# Altium schematic sheet master_schematic.SchDoc
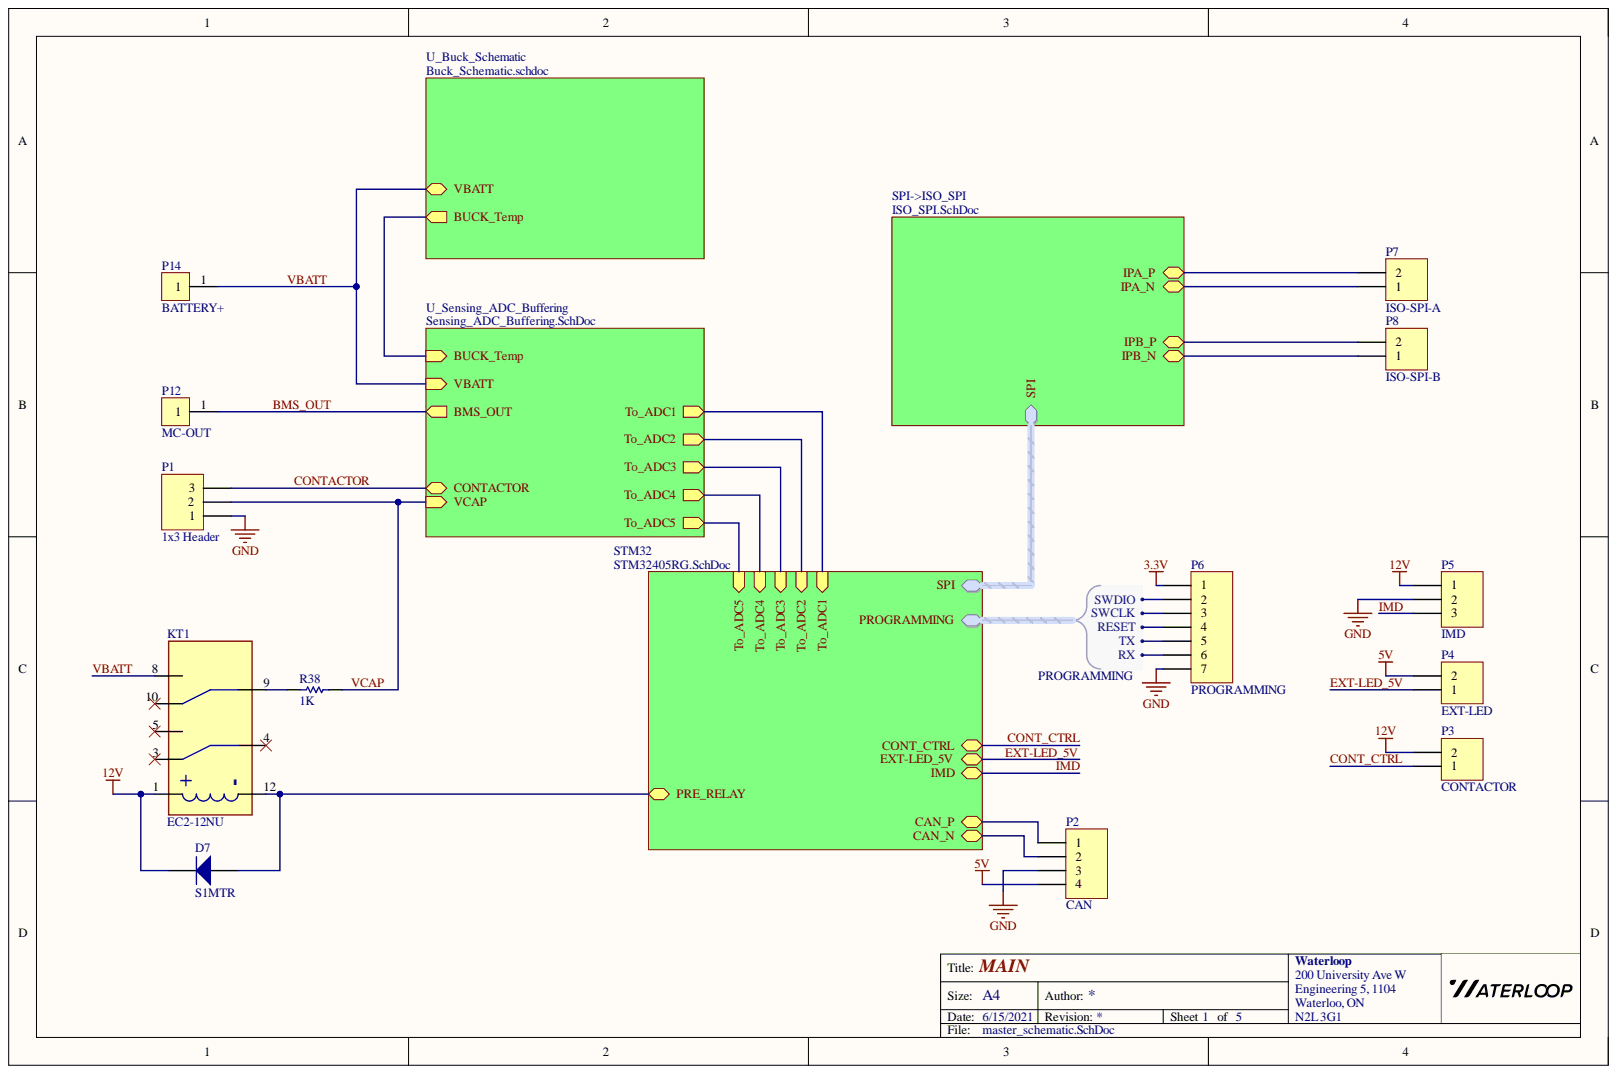
[diagram p0: master_schematic.SchDoc]

## Parts
D7  value S1MTR  [SMA - DIODE]  (hidden comment: Diode)
KT1  EC2-12NU  [EC2-12NU]
P1  1x3 Header  [B3B-XH]
P2  CAN  [B4B-XH]
P3  CONTACTOR  [B2B-XH]
P4  EXT-LED  [B2B-XH]
P5  IMD  [B3B-XH]
P6  PROGRAMMING  [HDR1X7]  (no BOM)
P7  ISO-SPI-A  [B2B-XH]
P8  ISO-SPI-B  [B2B-XH]
P12  MC-OUT  [74650094R]
P14  BATTERY+  [74650094R]
R38  1K  [2816 - RESISTOR]

## Nets
3.3V: P6.1
5V: P2.4 P4.2
12V: D7.2 KT1.1 P3.2 P5.1
GND: P1.1 P2.3 P5.2 P6.7
BMS_OUT: P12.1
CONT_CTRL: P3.1
CONTACTOR: P1.3
EXT-LED_5V: P4.1
IMD: P5.3
VBATT: KT1.8 P14.1
VCAP: P1.2 R38.2
NetD7_1: D7.1 KT1.12
NetKT1_3: KT1.3
NetKT1_4: KT1.4
NetKT1_5: KT1.5
NetKT1_9: KT1.9 R38.1
NetKT1_10: KT1.10
NetP2_1: P2.1
NetP2_2: P2.2
NetP6_2: P6.2
NetP6_3: P6.3
NetP6_4: P6.4
NetP6_5: P6.5
NetP6_6: P6.6
NetP7_1: P7.1
NetP7_2: P7.2
NetP8_1: P8.1
NetP8_2: P8.2
BUCK_Temp:
SPI.~{CS}:
SPI.~{CS2}:
SPI.CS:
SPI.MISO:
SPI.MOSI:
SPI.SCK:
To_ADC1:
To_ADC2:
To_ADC3:
To_ADC4:
To_ADC5:

## Library data of the parts
D7 = Diode: https://www.digikey.ca/en/products/detail/smc-diode-solutions/S1MTR/6022596; Component Kind: Standard; Library Name: General.SchLib; Signal Integrity: Diode; Simulation: DIODE
P1 P5 = 1x3 Header: 1x3 Pin Header; Component Kind: Standard; Library Name: GeneralConnectors.SchLib; Signal Integrity: Connector
P2 = 1x4 Header: 1x4 Pin Header; Component Kind: Standard; Library Name: GeneralConnectors.SchLib; Signal Integrity: Connector
P3 P4 P7 P8 = 1x2 Header: 1x2 Pin Header; Component Kind: Standard; Library Name: GeneralConnectors.SchLib; Signal Integrity: Connector
P6 = 1x7 Header: 1x7 Pin Header; Library Name: GeneralConnectors.SchLib; Signal Integrity: Connector
P12 P14 = 74650094R: Manufacturer: Wurth; Component Kind: Standard; Description: 74650094R; Library Name: GeneralConnectors.SchLib; Manufacturer Part Number: 74650094R; Supplier: Digikey; Supplier Part Number: 732-10884-1-ND
R38 = Resistor: 35401K0JT; Component Kind: Standard; Library Name: General.SchLib; Signal Integrity: Res; Simulation: RESISTOR
KT1 = EC2-12NU: Component Kind: Standard; Description: EC2-12NU; Library Name: BMS.SchLib
